# Altium schematic sheet osd.SchDoc
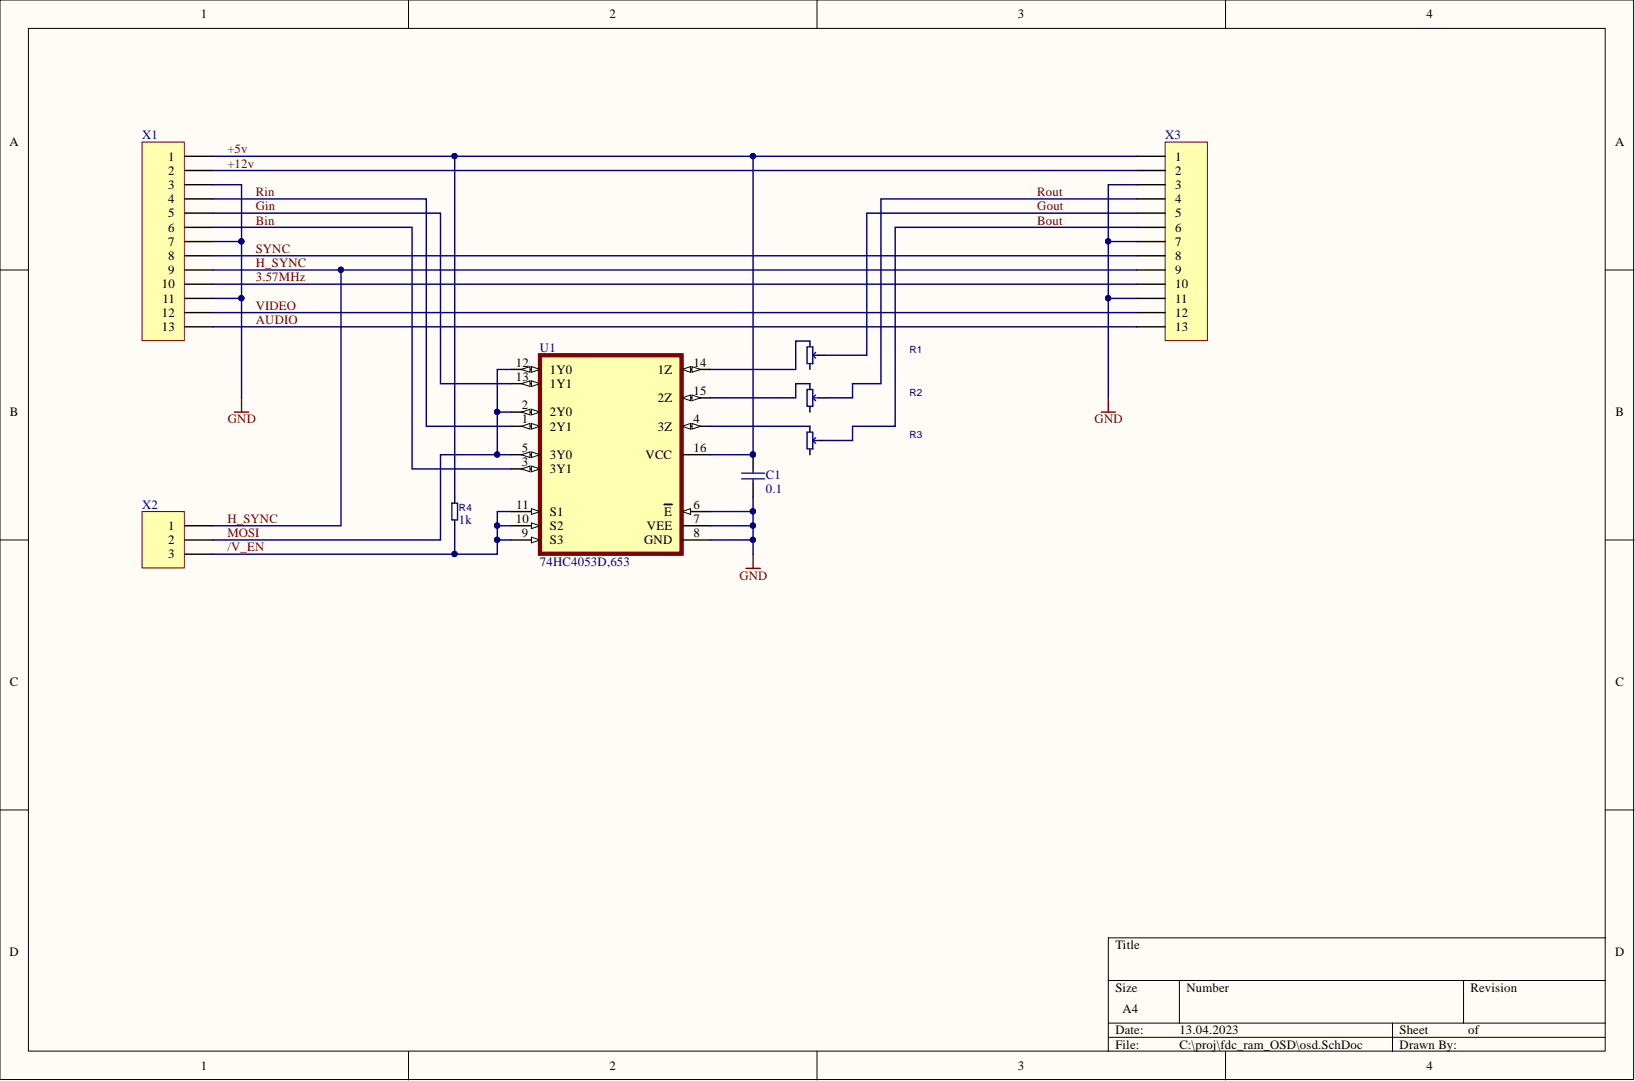
[diagram p0: osd.SchDoc]

## Parts
C1  value 0.1  [0603_cap]  (hidden comment: CAP_0.1uF_0603)
R1  R-var  [RES_variable]  (value not drawn)
R2  R-var  [RES_variable]  (value not drawn)
R3  R-var  [RES_variable]  (value not drawn)
R4  1k  [0603_RESISTOR]
U1  74HC4053D,653  [SOP127P600X175-16N]
X1  Header 13  [HDR1X13]  (value not drawn)
X2  Header 3  [HDR1X3]  (value not drawn)
X3  Header 13  [HDR1X13]  (value not drawn)

## Nets
GND: C1.1 U1.6(E) U1.7(VEE) U1.8(GND) X1.3 X1.7 X1.11 X3.3 X3.7 X3.11
3.57MHz: X1.10 X3.10
+5v: C1.2 R4.2 U1.16(VCC) X1.1 X3.1
+12v: X1.2 X3.2
/V_EN: R4.1 U1.9(S3) U1.10(S2) U1.11(S1) X2.3
AUDIO: X1.13 X3.13
Bin: U1.3(3Y1) X1.6
Bout: R3.2 X3.6
Gin: U1.13(1Y1) X1.5
Gout: R1.2 X3.5
H_SYNC: X1.9 X2.1 X3.9
MOSI: U1.2(2Y0) U1.5(3Y0) U1.12(1Y0) X2.2
Rin: U1.1(2Y1) X1.4
Rout: R2.2 X3.4
SYNC: X1.8 X3.8
VIDEO: X1.12 X3.12
NetR1_1: R1.1 U1.14(1Z)
NetR2_1: R2.1 U1.15(2Z)
NetR3_1: R3.1 U1.4(3Z)

Not connected: R1 3; R2 3; R3 3

## Library data of the parts
C1 = CAP_0.1uF_0603: CAP 0.1uF 63V CERAMIC X7R 0603; Manufacturer: Kemet; PartNumber: C0603C104K3RACTU; Component Kind: Standard; Datasheet link: http://www.kemet.com/kemet/web/homepage/kechome.nsf/vapubfilesname/F3102TA.pdf/$file/F3102TA.pdf; DigiKeyPartNumber: 399-1281-2-ND; Manufacturer URL: http://www.kemet.com/; RoHS: 1; Library Name: fdc_ram.SchLib
R1-R3 = R-var: variable resistor; Manufacturer: Generic; Rating: 63mW; Tolerance: 1%; Component Kind: Standard; Library Name: fdc_ram.SchLib
R4 = R-1k-0603: Resistor 1k 0603; Manufacturer: Generic; Rating: 63mW; Tolerance: 1%; Component Kind: Standard; value: 1k; Library Name: fdc_ram.SchLib
U1 = 74HC4053D,653: 3 Circuit IC Switch 2:1 120Ohm 16-SO; MF: Nexperia USA; Availability: Good; Component Kind: Standard; MP: 74HC4053D,653; Package: SO16-16 Nexperia USA Inc.; Price: None; Purchase-URL: https://pricing.snapeda.com/search/part/74HC4053D,653/?ref=eda; SnapEDA_Link: https://www.snapeda.com/parts/74HC4053D,653/Nexperia/view-part/612569/?ref=snap; Library Name: fdc_ram.SchLib
X1 X3 = Header 13: Header, 13-Pin; Component Kind: Standard; LatestRevisionDate: 17-Jul-2002; LatestRevisionNote: Re-released for DXP Platform.; Library Name: Miscellaneous Connectors.IntLib; Signal Integrity: Connector; Publisher: Altium Limited
X2 = Header 3: Header, 3-Pin; Component Kind: Standard; LatestRevisionDate: 17-Jul-2002; LatestRevisionNote: Re-released for DXP Platform.; Library Name: Miscellaneous Connectors.IntLib; Signal Integrity: Connector; Publisher: Altium Limited
